# Altium schematic sheet 5_Power-K230.schdoc(5_Power-K230)
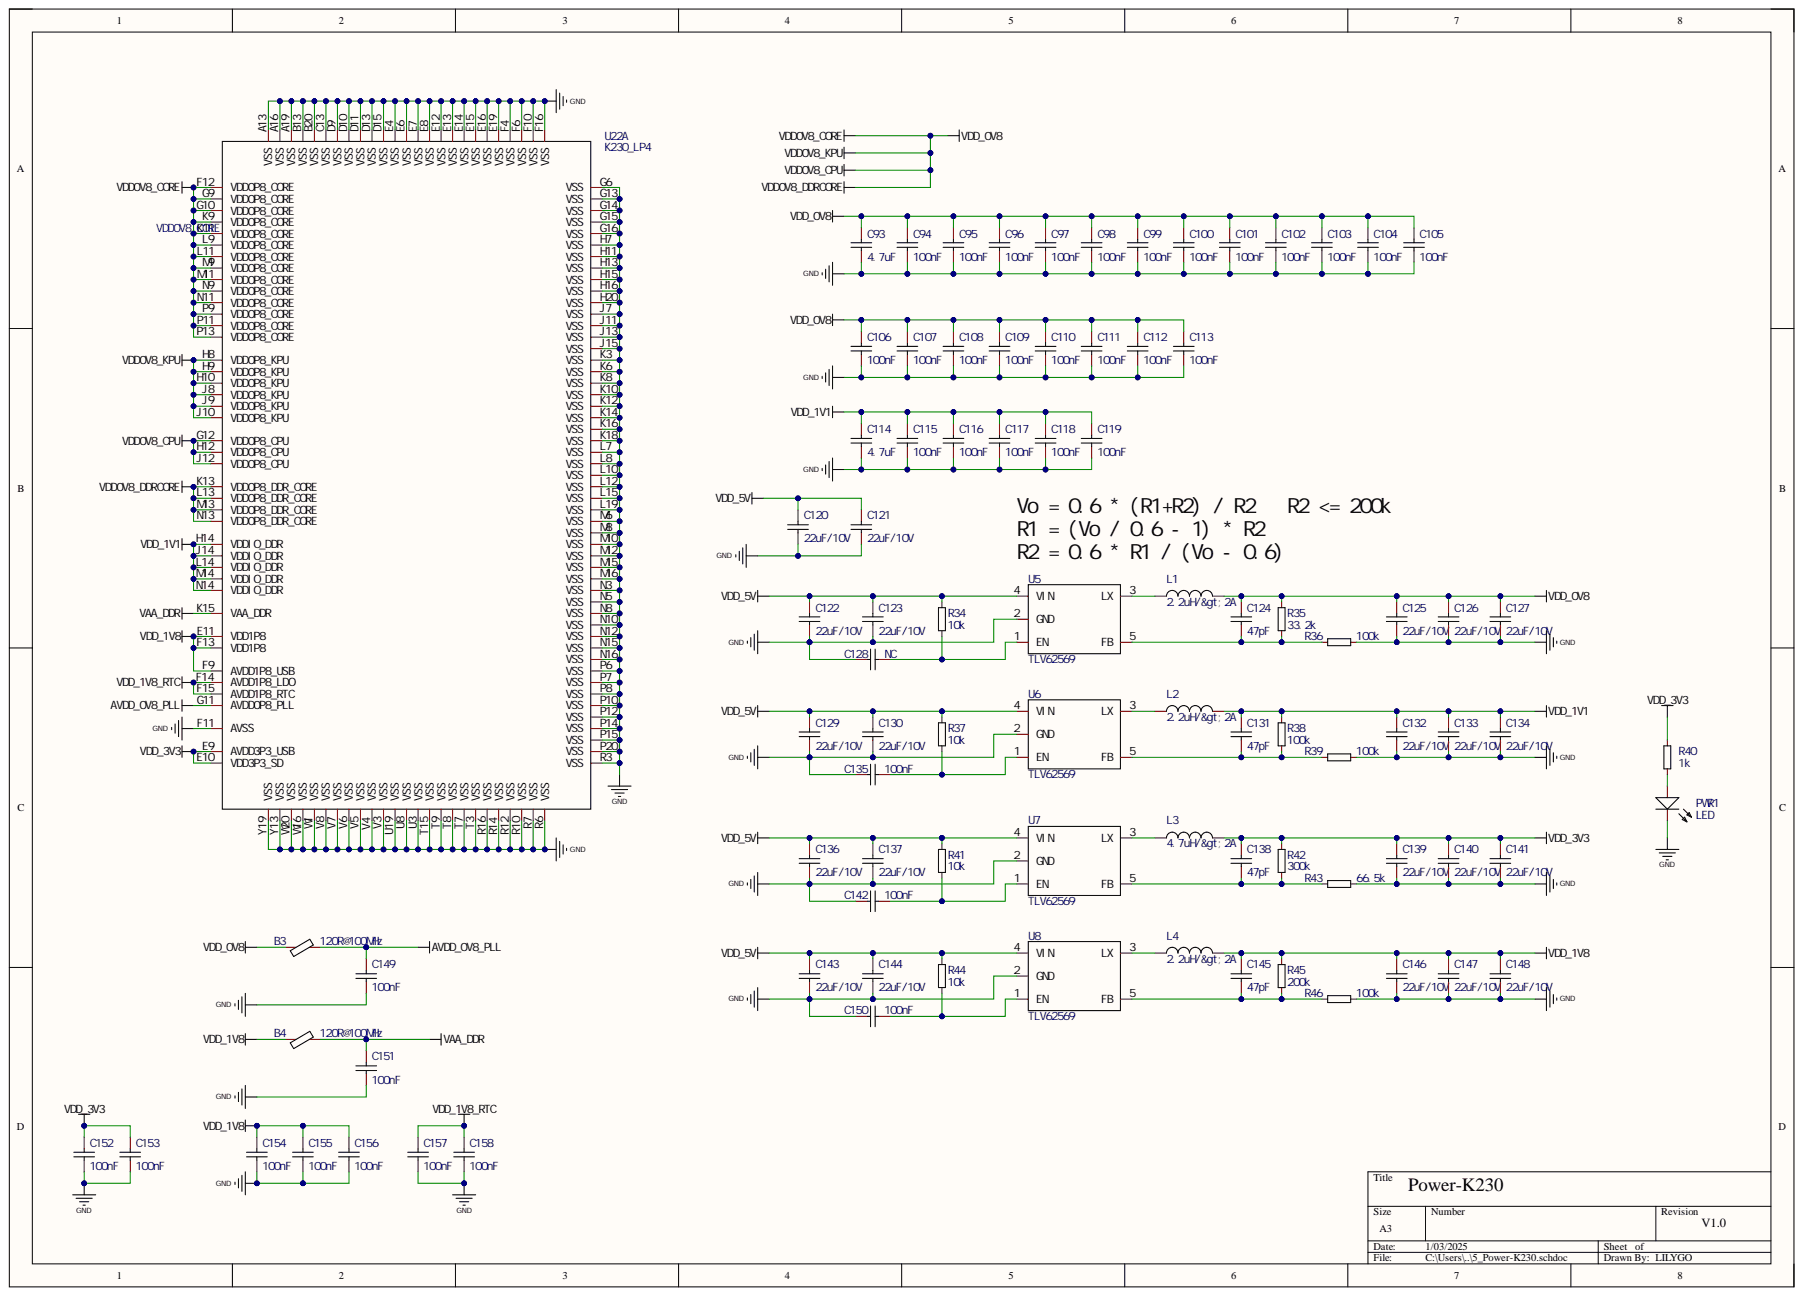
[diagram p0: 5_Power-K230.schdoc]

## Parts
B3  120R@100MHz  [R0201]
B4  120R@100MHz  [R0201]
C93  4.7uF  [c0402]
C94  100nF  [C0201]
C95  100nF  [C0201]
C96  100nF  [C0201]
C97  100nF  [C0201]
C98  100nF  [C0201]
C99  100nF  [C0201]
C100  100nF  [C0201]
C101  100nF  [C0201]
C102  100nF  [C0201]
C103  100nF  [C0201]
C104  100nF  [C0201]
C105  100nF  [C0201]
C106  100nF  [C0201]
C107  100nF  [C0201]
C108  100nF  [C0201]
C109  100nF  [C0201]
C110  100nF  [C0201]
C111  100nF  [C0201]
C112  100nF  [C0201]
C113  100nF  [C0201]
C114  4.7uF  [c0402]
C115  100nF  [C0201]
C116  100nF  [C0201]
C117  100nF  [C0201]
C118  100nF  [C0201]
C119  100nF  [C0201]
C120  22uF/10V  [c0603]
C121  22uF/10V  [c0603]
C122  22uF/10V  [c0603]
C123  22uF/10V  [c0603]
C124  47pF  [c0402]
C125  22uF/10V  [c0603]
C126  22uF/10V  [c0603]
C127  22uF/10V  [c0603]
C128  NC  [c0402]
C129  22uF/10V  [c0603]
C130  22uF/10V  [c0603]
C131  47pF  [c0402]
C132  22uF/10V  [c0603]
C133  22uF/10V  [c0603]
C134  22uF/10V  [c0603]
C135  100nF  [c0402]
C136  22uF/10V  [c0603]
C137  22uF/10V  [c0603]
C138  47pF  [c0402]
C139  22uF/10V  [c0603]
C140  22uF/10V  [c0603]
C141  22uF/10V  [c0603]
C142  100nF  [c0402]
C143  22uF/10V  [c0603]
C144  22uF/10V  [c0603]
C145  47pF  [c0402]
C146  22uF/10V  [c0603]
C147  22uF/10V  [c0603]
C148  22uF/10V  [c0603]
C149  100nF  [C0201]
C150  100nF  [c0402]
C151  100nF  [C0201]
C152  100nF  [C0201]
C153  100nF  [C0201]
C154  100nF  [C0201]
C155  100nF  [C0201]
C156  100nF  [C0201]
C157  100nF  [C0201]
C158  100nF  [C0201]
L1  2.2uH/&gt;2A  [3015]
L2  2.2uH/&gt;2A  [3015]
L3  4.7uH/&gt;2A  [3015]
L4  2.2uH/&gt;2A  [3015]
PWR1  LED  [0603_led_smt_nsk]
R34  10k  [r0402]
R35  33.2k  [r0402]
R36  100k  [r0402]
R37  10k  [r0402]
R38  100k  [r0402]
R39  100k  [r0402]
R40  1k  [r0402]
R41  10k  [r0402]
R42  300k  [r0402]
R43  66.5k  [r0402]
R44  10k  [r0402]
R45  200k  [r0402]
R46  100k  [r0402]
U5  TLV62569  [SOT23-5]
U6  TLV62569  [SOT23-5]
U7  TLV62569  [SOT23-5]
U8  TLV62569  [SOT23-5]
U22  K230_LP4  [K230]  (part A)

## Nets
AVDD_0V8_PLL: B3.2 C149.1 U22.G11(AVDD0P8_PLL)
GND: C93.2 C94.2 C95.2 C96.2 C97.2 C98.2 C99.2 C100.2 C101.2 C102.2 C103.2 C104.2 C105.2 C106.2 C107.2 C108.2 C109.2 C110.2 C111.2 C112.2 C113.2 C114.2 C115.2 C116.2 C117.2 C118.2 C119.2 C120.2 C121.2 C122.2 C123.2 C125.2 C126.2 C127.2 C128.1 C129.2 C130.2 C132.2 C133.2 C134.2 C135.1 C136.2 C137.2 C139.2 C140.2 C141.2 C142.1 C143.2 C144.2 C146.2 C147.2 C148.2 C149.2 C150.1 C151.2 C152.2 C153.2 C154.2 C155.2 C156.2 C157.2 C158.2 PWR1.2 R36.2 R39.2 R43.2 R46.2 U5.2(GND) U6.2(GND) U7.2(GND) U8.2(GND) U22.A13(VSS) U22.A16(VSS) U22.A19(VSS) U22.B13(VSS) U22.B20(VSS) U22.C13(VSS) U22.D9(VSS) U22.D10(VSS) U22.D11(VSS) U22.D13(VSS) U22.D15(VSS) U22.E4(VSS) U22.E6(VSS) U22.E7(VSS) U22.E8(VSS) U22.E12(VSS) U22.E13(VSS) U22.E14(VSS) U22.E15(VSS) U22.E16(VSS) U22.E19(VSS) U22.F4(VSS) U22.F6(VSS) U22.F10(VSS) U22.F11(AVSS) U22.F16(VSS) U22.G6(VSS) U22.G13(VSS) U22.G14(VSS) U22.G15(VSS) U22.G16(VSS) U22.H7(VSS) U22.H11(VSS) U22.H13(VSS) U22.H15(VSS) U22.H16(VSS) U22.H20(VSS) U22.J7(VSS) U22.J11(VSS) U22.J13(VSS) U22.J15(VSS) U22.K3(VSS) U22.K6(VSS) U22.K8(VSS) U22.K10(VSS) U22.K12(VSS) U22.K14(VSS) U22.K16(VSS) U22.K18(VSS) U22.L7(VSS) U22.L8(VSS) U22.L10(VSS) U22.L12(VSS) U22.L15(VSS) U22.L19(VSS) U22.M6(VSS) U22.M8(VSS) U22.M10(VSS) U22.M12(VSS) U22.M15(VSS) U22.M16(VSS) U22.N3(VSS) U22.N5(VSS) U22.N8(VSS) U22.N10(VSS) U22.N12(VSS) U22.N15(VSS) U22.N16(VSS) U22.P6(VSS) U22.P7(VSS) U22.P8(VSS) U22.P10(VSS) U22.P12(VSS) U22.P14(VSS) U22.P15(VSS) U22.P20(VSS) U22.R3(VSS) U22.R6(VSS) U22.R7(VSS) U22.R10(VSS) U22.R12(VSS) U22.R14(VSS) U22.R16(VSS) U22.T3(VSS) U22.T7(VSS) U22.T8(VSS) U22.T9(VSS) U22.T15(VSS) U22.U3(VSS) U22.U8(VSS) U22.U19(VSS) U22.V3(VSS) U22.V4(VSS) U22.V5(VSS) U22.V6(VSS) U22.V7(VSS) U22.V8(VSS) U22.W1(VSS) U22.W16(VSS) U22.W20(VSS) U22.Y13(VSS) U22.Y19(VSS)
VAA_DDR: B4.2 C151.1 U22.K15(VAA_DDR)
VDD_1V1: C114.1 C115.1 C116.1 C117.1 C118.1 C119.1 C131.1 C132.1 C133.1 C134.1 L2.2 R38.1 U22.H14(VDDIO_DDR) U22.J14(VDDIO_DDR) U22.L14(VDDIO_DDR) U22.M14(VDDIO_DDR) U22.N14(VDDIO_DDR)
VDD_1V8: B4.1 C145.1 C146.1 C147.1 C148.1 C154.1 C155.1 C156.1 L4.2 R45.1 U22.E11(VDD1P8) U22.F9(AVDD1P8_USB) U22.F13(VDD1P8)
VDD_1V8_RTC: C157.1 C158.1 U22.F14(AVDD1P8_LDO) U22.F15(AVDD1P8_RTC)
VDD_3V3: C138.1 C139.1 C140.1 C141.1 C152.1 C153.1 L3.2 R40.1 R42.1 U22.E9(AVDD3P3_USB) U22.E10(VDD3P3_SD)
VDD_5V: C120.1 C121.1 C122.1 C123.1 C129.1 C130.1 C136.1 C137.1 C143.1 C144.1 R34.1 R37.1 R41.1 R44.1 U5.4(VIN) U6.4(VIN) U7.4(VIN) U8.4(VIN)
VDD0V8_CORE: B3.1 C93.1 C94.1 C95.1 C96.1 C97.1 C98.1 C99.1 C100.1 C101.1 C102.1 C103.1 C104.1 C105.1 C106.1 C107.1 C108.1 C109.1 C110.1 C111.1 C112.1 C113.1 C124.1 C125.1 C126.1 C127.1 L1.2 R35.1 U22.F12(VDD0P8_CORE) U22.G9(VDD0P8_CORE) U22.G10(VDD0P8_CORE) U22.G12(VDD0P8_CPU) U22.H8(VDD0P8_KPU) U22.H9(VDD0P8_KPU) U22.H10(VDD0P8_KPU) U22.H12(VDD0P8_CPU) U22.J8(VDD0P8_KPU) U22.J9(VDD0P8_KPU) U22.J10(VDD0P8_KPU) U22.J12(VDD0P8_CPU) U22.K9(VDD0P8_CORE) U22.K11(VDD0P8_CORE) U22.K13(VDD0P8_DDR_CORE) U22.L9(VDD0P8_CORE) U22.L11(VDD0P8_CORE) U22.L13(VDD0P8_DDR_CORE) U22.M9(VDD0P8_CORE) U22.M11(VDD0P8_CORE) U22.M13(VDD0P8_DDR_CORE) U22.N9(VDD0P8_CORE) U22.N11(VDD0P8_CORE) U22.N13(VDD0P8_DDR_CORE) U22.P9(VDD0P8_CORE) U22.P11(VDD0P8_CORE) U22.P13(VDD0P8_CORE)
NetC124_2: C124.2 R35.2 R36.1 U5.5(FB)
NetC128_2: C128.2 R34.2 U5.1(EN)
NetC131_2: C131.2 R38.2 R39.1 U6.5(FB)
NetC135_2: C135.2 R37.2 U6.1(EN)
NetC138_2: C138.2 R42.2 R43.1 U7.5(FB)
NetC142_2: C142.2 R41.2 U7.1(EN)
NetC145_2: C145.2 R45.2 R46.1 U8.5(FB)
NetC150_2: C150.2 R44.2 U8.1(EN)
NetL1_1: L1.1 U5.3(LX)
NetL2_1: L2.1 U6.3(LX)
NetL3_1: L3.1 U7.3(LX)
NetL4_1: L4.1 U8.3(LX)
NetPWR1_1: PWR1.1 R40.2

## Library data of the parts
B3 B4 = BEAD: Add into BOM: yes; Comment_1: 120R@100MHz; Component Kind: Standard; Convert to PCB: yes; Device: BEAD; Symbol: BEAD
C93-C119 C149 C151-C158 = 0201_CAP: Add into BOM: yes; Symbol: CAP; Component Kind: Standard; Convert to PCB: yes; Device: 0201_CAP; PCB3D: 0201-CAP
  C93 C114: Comment_1: 4.7uF
  C94-C113 C115-C119 C149 C151-C158: Comment_1: 100nF
C120-C148 C150 = CAP: Add into BOM: yes; Component Kind: Standard; Convert to PCB: yes; Device: CAP; Symbol: CAP
  C120-C123 C125-C127 C129 C130 C132-C134 C136 C137 C139-C141 C143 C144 C146-C148: Comment_1: 22uF/10V
  C124 C131 C138 C145: Comment_1: 47pF
  C128: Comment_1: NC
  C135 C142 C150: Comment_1: 100nF
L1-L4 = Inductor: Add into BOM: yes; Component Kind: Standard; Convert to PCB: yes; Device: Inductor; PCB3D: inductor_3x3mm; Symbol: Inductor
  L1 L2 L4: Comment_1: 2.2uH/&gt;2A
  L3: Comment_1: 4.7uH/&gt;2A
PWR1 = LED: Add into BOM: yes; Comment_1: LED; Component Kind: Standard; Convert to PCB: yes; Device: LED; PCB3D: 0603-CAP; Symbol: LED
R34-R46 = RES: Add into BOM: yes; Component Kind: Standard; Convert to PCB: yes; Device: RES; Symbol: RES
  R34 R37 R41 R44: Comment_1: 10k
  R35: Comment_1: 33.2k
  R36 R38 R39 R46: Comment_1: 100k
  R40: Comment_1: 1k
  R42: Comment_1: 300k
  R43: Comment_1: 66.5k
  R45: Comment_1: 200k
U5-U8 = TLV62569DBVR: Add into BOM: yes; Comment_1: TLV62569; Component Kind: Standard; Convert to PCB: yes; Device: TLV62569DBVR; Symbol: TLV62569DBVR
U22 = K230_LP4: Add into BOM: yes; Comment_1: K230_LP4; Component Kind: Standard; Convert to PCB: yes; Device: K230_LP4; Library Name: CanMV-K230-LP4-V3.0.SCHLIB; PCB3D: K230; Symbol: K230_LP4
